# Altium schematic sheet cross_connect.SchDoc
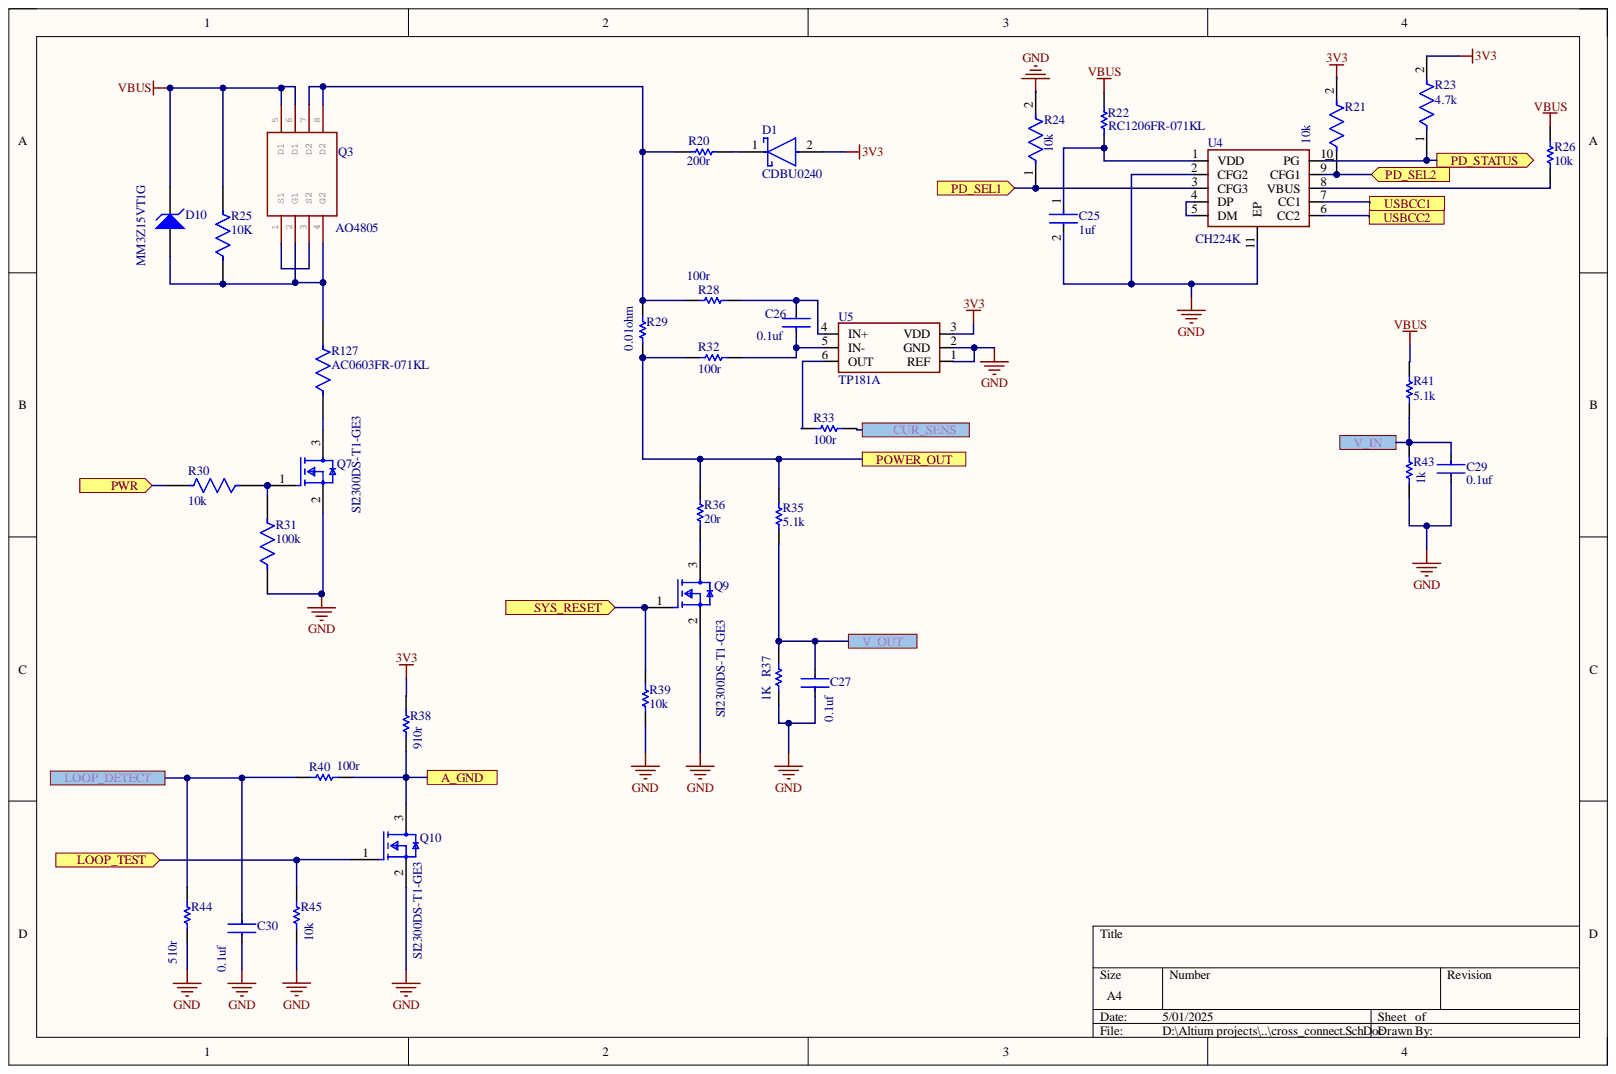
[diagram p0: cross_connect.SchDoc]

## Parts
C25  1uf  [FP-GRM188-0_1-e0_2_0_55-IPC_C]
C26  0.1uf  [FP-GRM188-0_1-e0_2_0_55-IPC_C]
C27  0.1uf  [FP-GRM188-0_1-e0_2_0_55-IPC_C]
C29  0.1uf  [FP-GRM188-0_1-e0_2_0_55-IPC_C]
C30  0.1uf  [FP-GRM188-0_1-e0_2_0_55-IPC_C]
D1  CDBU0240  [FP-SOD-523F-MFG]
D10  MM3Z15VT1G  [ONSC-SOD-323-2-477-02_V]
Q3  AO4805  [SOP8]
Q7  SI2300DS-T1-GE3  [FP-S-03946-MFG]
Q9  SI2300DS-T1-GE3  [FP-S-03946-MFG]
Q10  SI2300DS-T1-GE3  [FP-S-03946-MFG]
R20  200r  [RESC1609X50X30NL8T20]
R21  10k  [FP-RC0603-0_55-MFG]
R22  RC1206FR-071KL  [RESC3116X65X40ML10T20]
R23  4.7k  [FP-AC0603-MFG]
R24  10k  [FP-RC0603-0_55-MFG]
R25  10K  [FP-RC0603-0_55-MFG]
R26  10k  [RESC1609X50X30NL8T20]
R28  100r  [RESC1609X50X30NL8T20]
R29  0.01ohm  [RESC3216X70X50LL05T20]
R30  10k  [FP-RC0603-0_55-MFG]
R31  100k  [FP-RC0603-0_55-MFG]
R32  100r  [RESC1609X50X30NL8T20]
R33  100r  [RESC1609X50X30NL8T20]
R35  5.1k  [RESC1609X50X30NL8T20]
R36  20r  [RESC3216X70X50LL05T20]
R37  1K  [RESC1609X50X30NL8T20]
R38  910r  [RESC1609X50X30NL8T20]
R39  10k  [RESC1609X50X30NL8T20]
R40  100r  [RESC1609X50X30NL8T20]
R41  5.1k  [RESC1609X50X30NL8T20]
R43  1k  [RESC1609X50X30NL8T20]
R44  510r  [RESC1609X50X30NL8T20]
R45  10k  [RESC1609X50X30NL8T20]
R127  AC0603FR-071KL  [FP-AC0603-IPC_B]
U4  CH224K  [SOP10]
U5  TP181A  [SOP6]

## Ports
A_GND, CUR_SENS, LOOP_DETECT, LOOP_TEST, PD_SEL1, PD_SEL2, PD_STATUS, POWER_OUT, PWR, SYS_RESET, USBCC1, USBCC2, V_IN, V_OUT

## Nets
3V3: D1.2 R21.2 R23.2 R38.2 U5.3(VDD)
GND: C25.2 C27.1 C29.2 C30.2 Q7.2 Q9.2 Q10.2 R24.2 R31.1 R37.1 R39.1 R43.2 R44.1 R45.1 U4.2(CFG2) U4.11(EP) U5.1(REF) U5.2(GND)
VBUS: D10.1 Q3.5(D1) Q3.6(D1) R22.2 R25.2 R26.1 R41.1
A_GND: Q10.3 R38.1 R40.1 ; port A_GND
CUR_SENS: R33.1 ; port CUR_SENS
LOOP_DETECT: C30.1 R40.2 R44.2 ; port LOOP_DETECT
LOOP_TEST: Q10.1 R45.2 ; port LOOP_TEST
PD_SEL1: R24.1 U4.3(CFG3) ; port PD_SEL1
PD_SEL2: R21.1 U4.9(CFG1) ; port PD_SEL2
PD_STATUS: R23.1 U4.10(PG) ; port PD_STATUS
POWER_OUT: R29.2 R32.1 R35.2 R36.2 ; port POWER_OUT
PWR: R30.2 ; port PWR
SYS_RESET: Q9.1 R39.2 ; port SYS_RESET
USBCC1: U4.7(CC1) ; port USBCC1
USBCC2: U4.6(CC2) ; port USBCC2
V_IN: C29.1 R41.2 R43.1 ; port V_IN
V_OUT: C27.2 R35.1 R37.2 ; port V_OUT
NetC25_1: C25.1 R22.1 U4.1(VDD)
NetC26_1: C26.1 R32.2 U5.5(IN-)
NetC26_2: C26.2 R28.2 U5.4(IN+)
NetD1_1: D1.1 R20.1
NetD10_2: D10.2 Q3.2(G1) Q3.4(G2) R25.1 R127.2
NetQ3_1: Q3.1(S1) Q3.3(S2)
NetQ3_7: Q3.7(D2) Q3.8(D2) R20.2 R28.1 R29.1
NetQ7_1: Q7.1 R30.1 R31.2
NetQ7_3: Q7.3 R127.1
NetQ9_3: Q9.3 R36.1
NetR26_2: R26.2 U4.8(VBUS)
NetR33_2: R33.2 U5.6(OUT)
NetU4_4: U4.4(DP) U4.5(DM)

## Library data of the parts
C25 = CMP-2006-02792-2: Chip Multilayer Ceramic Capacitors for General Purpose, 0603, 1uF, X5R, 15%, 20%, 6.3V; Capacitance: 10uF; Case Code (Imperial): 0603; Case Code (Metric): 1608; Case/Package: 0603; Component Kind: Standard; Depth: 0.8mm; Dielectric: X5R; Height: 0.8mm; Lead Free: Lead Free; Length: 1.6mm; Library Name: Altium Content Vault; Material: Ceramic; Max Operating Temperature: 85°C; Min Operating Temperature: -55°C; Mount: Surface Mount; Package Quantity: 4000; Packaging: Tape and Reel; Radiation Hardening: No; REACH SVHC: No SVHC; Termination: SMD/SMT; Thickness: 0.035inch; Tolerance: 20%; Voltage: 6.3V; Voltage Rating: 6.3V; Voltage Rating (DC): 6.3V; Width: 0.031inch
C26 C27 C29 C30 = CMP-2006-02792-2: Chip Multilayer Ceramic Capacitors for General Purpose, 0603, 0.1uF, X5R, 15%, 20%, 6.3V; Capacitance: 10uF; Case Code (Imperial): 0603; Case Code (Metric): 1608; Case/Package: 0603; Component Kind: Standard; Depth: 0.8mm; Dielectric: X5R; Height: 0.8mm; Lead Free: Lead Free; Length: 1.6mm; Library Name: Altium Content Vault; Material: Ceramic; Max Operating Temperature: 85°C; Min Operating Temperature: -55°C; Mount: Surface Mount; Package Quantity: 4000; Packaging: Tape and Reel; Radiation Hardening: No; REACH SVHC: No SVHC; Termination: SMD/SMT; Thickness: 0.035inch; Tolerance: 20%; Voltage: 6.3V; Voltage Rating: 6.3V; Voltage Rating (DC): 6.3V; Width: 0.031inch
D1 = CMP-20227-000007-1: DIODE SCHOTTKY 40V 200MA 0603; Capacitance: 9pF; Max Power Dissipation: 150mW; Max Reverse Leakage Current: 1uA; Max Surge Current: 2A; Polarity: Standard; Average Rectified Current: 200mA; Case/Package: SMD/SMT; Component Kind: Standard; Current Rating: 200mA; Lead Free: Lead Free; Library Name: Altium Content Vault; Max Reverse Voltage (DC): 40V; Mount: Surface Mount; Output Current: 200mA; Package Quantity: 1; Packaging: Tape and Reel; RoHS Compliant: Yes; Voltage Rating (DC): 40V
D10 = CMP-1060-00722-1: Zener Voltage Regulator, 300 mW, 2-Pin SOD-323, Pb-Free, Tape and Reel; Manufacturer: On Semiconductor; P Max (W): 0.3; VZ Typ (V): 15; Component Kind: Standard; ComponentLink1Description: Manufacturer URL; ComponentLink1URL: http://www.onsemi.com/; ComponentLink2Description: Datasheet; ComponentLink2URL: http://www.onsemi.cn/pub_link/Collateral/MM3Z2V4T1-D.PDF; ComponentLink3Description: Package Specification; ComponentLink3URL: http://www.onsemi.com/pub_link/Collateral/477-02.PDF.1; DatasheetVersion: Rev. 9; Library Name: Altium Content Vault; Mounting Technology: Surface Mount; PackageDescription: 2-Pin SOD, Body 1.7 x 1.25 mm; PackageReference: SOD-323-2-477-02; PackageVersion: Rev. H, 03/2007; Packing: Tape and Reel; PartNumber: MM3Z15VT1G; RoHS: Pb-Free
Q7 Q9 Q10 = CMP-02550-000182-1: MOSFET N-CH 30V 3.6A SOT-23; Manufacturer: Vishay; Continuous Drain Current: 3.1A; Depletion Mode: False; Drain To Source Resistance: 0.055Ω; Gate Charge At Vgs: 6.5nC @ 10V; Gate To Source Voltage: 12V; Input Capacitace At Vds: 320pF @ 15V; Max Forward Diode Voltage: 1.2V; Max Junction Temp: +150°C; Number Of Channels: 1; Power Dissipation: 1.1W; Pulse Drain Current: 15A; Reverse Recovery Charge: 5nC; Reverse Recovery Time: 11ns; Rthja Max: 90°C/W; Standoff Height: 0.01mm; Threshold Vgs Max: 1.5V; Threshold Vgs Min: 0.6V; Transconductance: 13S; Turn Off Delay Time: 15ns; Turn On Delay Time: 5ns; Typ Forward Diode Voltage: 0.8V; Automotive: No; Category: Trans; Component Kind: Standard; ComponentLink2Description: Datasheet; ComponentLink2URL: http://www.vishay.com/docs/65701/si2300ds.pdf; Device Class L1: Discrete Semiconductors; Device Class L2: Transistors; Device Class L3: MOSFETs; Digikey Description: MOSFET N-CH 30V 3.6A SOT-23; Drain To Source Breakdown Voltage: 30V; Drain To Source Voltage: 30V; Height: 1.12mm; Ipc Land Pattern Name: SOT95P237X100-3; Lead Free: Yes; Library Name: Altium Content Vault; Manufacturer Part Number: SI2300DS-T1-GE3; Mouser Description: MOSFET 30V 3.6A N-CH MOSFET; Number Of N Channels: 1; Octopart: https://octopart.com/si2300ds-t1-ge3-vishay-44451512; Package: S-03946; RoHS: TRUE; Temperature: -55°C to +150°C; Temperature Range High: +150°C; Temperature Range Low: -55°C
R20 = CMP-1666-00005-4: Chip Resistor, 200 Ohm, +/- 1%, 100 mW, -55 to 155 degC, 0603 (1608 Metric), RoHS, Tape and Reel; Manufacturer: Vishay Semiconductor; Voltage Rating: 75 V; Component Kind: Standard; ComponentLink1Description: Manufacturer URL; ComponentLink1URL: http://www.vishay.com/; ComponentLink2Description: Datasheet; ComponentLink2URL: https://datasheet.ciiva.com/11809/0900766b80d98afc-11809037.pdf; DatasheetVersion: 06/2012; Height: 0.45 mm; Length: 1.55 mm; Library Name: Altium Content Vault; Max Operating Temperature: 155 degC; Min Operating Temperature: -55 degC; Mounting Technology: Surface Mount; Package Quantity: 5000; PackageDescription: 2-Pin Surface Mount Device, Body 1.575 x 0.85 mm; PackageReference: 0603; Packaging: Tape and Reel; REACH SVHC: Unknown; RoHSCompliant: true
R21 R24 R25 R30 R31 = CMP-2100-03664-2: RES 10K OHM 1% 1/10W 0603; Max Power Dissipation: 100mW; Resistance: 10kR; Temperature Coefficient: 100ppm/°C; Voltage Rating: 75V; Voltage Rating (DC): 50V; Case Code (Imperial): 0603; Case Code (Metric): 1608; Case/Package: 0603; Component Kind: Standard; Construction: Rectangular; Depth: 0.8mm; Features: Moisture Resistant; Height: 0.45mm; Lead Free: Lead Free; Lead Pitch: 0.3mm; Length: 1.6mm; Library Name: Altium Content Vault; Max Operating Temperature: 155°C; Military Standard: Not; Min Operating Temperature: -55°C; Number of Pins: 2; Number of Terminations: 2; Packaging: Tape and Reel; Power Rating: 100mW; Resistance Tolerance: 1%; Resistor Type: Thick Film; RoHS Compliant: Yes; Series: RC; Size Code: 0603; Termination: SMD/SMT; Tolerance: 1%; Weight: 7.1E-05oz; Width: 0.8mm
R22 = CMP-2100-03678-1: Chip Resistor, 1 KOhm, +/- 1%, 0.25 W, -55 to 155 degC, 1206 (3216 Metric), RoHS, Tape and Reel; Manufacturer: Yageo; Power Rating: 250mW; Resistance: 1KOhm; Temperature Coefficient: 100ppm/degreesC; Voltage Rating: 200V; Case Code (Imperial): 1206; Case Code (Metric): 3216; Case/Package: 1206; Component Kind: Standard; Composition: ThickFilm; Datasheet URL: http://www.yageo.com/NewPortal/yageodocoutput?fileName=/pdf/R-Chip/PYu-RC_Group_51_RoHS_L_5.pdf; Datasheet Version: Rev. 5, 10/2016; Depth: 1.6mm; Height: 0.55mm; Lead Free: LeadFree; Length: 3.1mm; Library Name: Altium Content Vault; Manufacturer URL: http://www.yageo.com/; Max Operating Temperature: 155degC; Military Standard: Not; Min Operating Temperature: -55degC; Package Description: 2-Pin Surface Mount Device, Body 3.1 x 1.6 mm; Package Reference: 1206.0; Packaging: TapeandReel; REACH SVHC: Unknown; RoHSCompliant: true; Termination: SMD/SMT; Tolerance: 1%
R23 = CMP-2000-04847-3: RES 4.7KΩ 1.00% 0.1W 0603; Max Power Dissipation: 100mW; Resistance: 4.7kR; Temperature Coefficient: 100ppm/°C; Tolerance: 1%; Voltage Rating: 50V; Case Code (Imperial): 0603; Case Code (Metric): 1608; Case/Package: 0603; Component Kind: Standard; Construction: Rectangular; Depth: 0.8mm; Features: Moisture Resistant; Height: 0.022inch; Lead Free: Lead Free; Length: 0.063inch; Library Name: Altium Content Vault; Max Operating Temperature: 155°C; Military Standard: Not; Min Operating Temperature: -55°C; Number of Terminations: 2; Packaging: Tape and Reel; Power Rating: 100mW; Ratings: AEC-Q200; Resistor Type: Thick Film; RoHS Compliant: Yes; Series: AC; Size Code: 0603; Termination: SMD/SMT; Width: 0.031inch
R26 R39 R45 = CMP-1666-00005-4: Chip Resistor, 10 KOhm, +/- 1%, 100 mW, -55 to 155 degC, 0603 (1608 Metric), RoHS, Tape and Reel; Manufacturer: Vishay Semiconductor; Voltage Rating: 75 V; Component Kind: Standard; ComponentLink1Description: Manufacturer URL; ComponentLink1URL: http://www.vishay.com/; ComponentLink2Description: Datasheet; ComponentLink2URL: https://datasheet.ciiva.com/11809/0900766b80d98afc-11809037.pdf; DatasheetVersion: 06/2012; Height: 0.45 mm; Length: 1.55 mm; Library Name: Altium Content Vault; Max Operating Temperature: 155 degC; Min Operating Temperature: -55 degC; Mounting Technology: Surface Mount; Package Quantity: 5000; PackageDescription: 2-Pin Surface Mount Device, Body 1.575 x 0.85 mm; PackageReference: 0603; Packaging: Tape and Reel; REACH SVHC: Unknown; RoHSCompliant: true
R28 R32 R33 R40 = CMP-1666-00005-4: Chip Resistor, 100 Ohm, +/- 1%, 100 mW, -55 to 155 degC, 0603 (1608 Metric), RoHS, Tape and Reel; Manufacturer: Vishay Semiconductor; Voltage Rating: 75 V; Component Kind: Standard; ComponentLink1Description: Manufacturer URL; ComponentLink1URL: http://www.vishay.com/; ComponentLink2Description: Datasheet; ComponentLink2URL: https://datasheet.ciiva.com/11809/0900766b80d98afc-11809037.pdf; DatasheetVersion: 06/2012; Height: 0.45 mm; Length: 1.55 mm; Library Name: Altium Content Vault; Max Operating Temperature: 155 degC; Min Operating Temperature: -55 degC; Mounting Technology: Surface Mount; Package Quantity: 5000; PackageDescription: 2-Pin Surface Mount Device, Body 1.575 x 0.85 mm; PackageReference: 0603; Packaging: Tape and Reel; REACH SVHC: Unknown; RoHSCompliant: true
R29 = CMP-2003-00020-1: 0.01 ohm 1206; Manufacturer: Panasonic; Part Number: ERJ-8ENF1004V; Component Kind: Standard; Library Name: Altium Content Vault
R35 = CMP-1666-00005-4: Chip Resistor, 5.1 KOhm, +/- 1%, 100 mW, -55 to 155 degC, 0603 (1608 Metric), RoHS, Tape and Reel; Manufacturer: Vishay Semiconductor; Voltage Rating: 75 V; Component Kind: Standard; ComponentLink1Description: Manufacturer URL; ComponentLink1URL: http://www.vishay.com/; ComponentLink2Description: Datasheet; ComponentLink2URL: https://datasheet.ciiva.com/11809/0900766b80d98afc-11809037.pdf; DatasheetVersion: 06/2012; Height: 0.45 mm; Length: 1.55 mm; Library Name: Altium Content Vault; Max Operating Temperature: 155 degC; Min Operating Temperature: -55 degC; Mounting Technology: Surface Mount; Package Quantity: 5000; PackageDescription: 2-Pin Surface Mount Device, Body 1.575 x 0.85 mm; PackageReference: 0603; Packaging: Tape and Reel; REACH SVHC: Unknown; RoHSCompliant: true
R36 = CMP-2003-00020-1: 20r 1206; Manufacturer: Panasonic; Part Number: ERJ-8ENF1004V; Component Kind: Standard; Library Name: Altium Content Vault
R37 R43 = CMP-1666-00005-4: Chip Resistor, 1 KOhm, +/- 1%, 100 mW, -55 to 155 degC, 0603 (1608 Metric), RoHS, Tape and Reel; Manufacturer: Vishay Semiconductor; Voltage Rating: 75 V; Component Kind: Standard; ComponentLink1Description: Manufacturer URL; ComponentLink1URL: http://www.vishay.com/; ComponentLink2Description: Datasheet; ComponentLink2URL: https://datasheet.ciiva.com/11809/0900766b80d98afc-11809037.pdf; DatasheetVersion: 06/2012; Height: 0.45 mm; Length: 1.55 mm; Library Name: Altium Content Vault; Max Operating Temperature: 155 degC; Min Operating Temperature: -55 degC; Mounting Technology: Surface Mount; Package Quantity: 5000; PackageDescription: 2-Pin Surface Mount Device, Body 1.575 x 0.85 mm; PackageReference: 0603; Packaging: Tape and Reel; REACH SVHC: Unknown; RoHSCompliant: true
R38 = CMP-1666-00005-4: Chip Resistor, 910 Ohm, +/- 1%, 100 mW, -55 to 155 degC, 0603 (1608 Metric), RoHS, Tape and Reel; Manufacturer: Vishay Semiconductor; Voltage Rating: 75 V; Component Kind: Standard; ComponentLink1Description: Manufacturer URL; ComponentLink1URL: http://www.vishay.com/; ComponentLink2Description: Datasheet; ComponentLink2URL: https://datasheet.ciiva.com/11809/0900766b80d98afc-11809037.pdf; DatasheetVersion: 06/2012; Height: 0.45 mm; Length: 1.55 mm; Library Name: Altium Content Vault; Max Operating Temperature: 155 degC; Min Operating Temperature: -55 degC; Mounting Technology: Surface Mount; Package Quantity: 5000; PackageDescription: 2-Pin Surface Mount Device, Body 1.575 x 0.85 mm; PackageReference: 0603; Packaging: Tape and Reel; REACH SVHC: Unknown; RoHSCompliant: true
R41 = CMP-1666-00005-4: Chip Resistor, 5.1k Ohm, +/- 1%, 100 mW, -55 to 155 degC, 0603 (1608 Metric), RoHS, Tape and Reel; Manufacturer: Vishay Semiconductor; Voltage Rating: 75 V; Component Kind: Standard; ComponentLink1Description: Manufacturer URL; ComponentLink1URL: http://www.vishay.com/; ComponentLink2Description: Datasheet; ComponentLink2URL: https://datasheet.ciiva.com/11809/0900766b80d98afc-11809037.pdf; DatasheetVersion: 06/2012; Height: 0.45 mm; Length: 1.55 mm; Library Name: Altium Content Vault; Max Operating Temperature: 155 degC; Min Operating Temperature: -55 degC; Mounting Technology: Surface Mount; Package Quantity: 5000; PackageDescription: 2-Pin Surface Mount Device, Body 1.575 x 0.85 mm; PackageReference: 0603; Packaging: Tape and Reel; REACH SVHC: Unknown; RoHSCompliant: true
R44 = CMP-1666-00005-4: Chip Resistor, 510 Ohm, +/- 1%, 100 mW, -55 to 155 degC, 0603 (1608 Metric), RoHS, Tape and Reel; Manufacturer: Vishay Semiconductor; Voltage Rating: 75 V; Component Kind: Standard; ComponentLink1Description: Manufacturer URL; ComponentLink1URL: http://www.vishay.com/; ComponentLink2Description: Datasheet; ComponentLink2URL: https://datasheet.ciiva.com/11809/0900766b80d98afc-11809037.pdf; DatasheetVersion: 06/2012; Height: 0.45 mm; Length: 1.55 mm; Library Name: Altium Content Vault; Max Operating Temperature: 155 degC; Min Operating Temperature: -55 degC; Mounting Technology: Surface Mount; Package Quantity: 5000; PackageDescription: 2-Pin Surface Mount Device, Body 1.575 x 0.85 mm; PackageReference: 0603; Packaging: Tape and Reel; REACH SVHC: Unknown; RoHSCompliant: true
R127 = CMP-2000-04828-3: RES 1kΩ 1.00% 0.1W 0603; Max Power Dissipation: 100mW; Resistance: 1kR; Temperature Coefficient: 100ppm/°C; Tolerance: 1%; Voltage Rating: 50V; Case Code (Imperial): 0603; Case Code (Metric): 1608; Case/Package: 0603; Component Kind: Standard; Construction: Rectangular; Depth: 0.8mm; Features: Moisture Resistant; Height: 0.022inch; Lead Free: Lead Free; Length: 0.063inch; Library Name: Altium Content Vault; Max Operating Temperature: 155°C; Military Standard: Not; Min Operating Temperature: -55°C; Number of Terminations: 2; Package Quantity: 1; Packaging: Tape and Reel; Power Rating: 100mW; Ratings: AEC-Q200; Resistor Type: Thick Film; RoHS Compliant: Yes; Series: AC; Size Code: 0603; Termination: SMD/SMT; Width: 0.031inch
Q3 = AO4805: Component Kind: Standard; Description: AO4805; Library Name: AON6403.SCHLIB
U4 = CH224K: Component Kind: Standard; Library Name: Schlib1.SchLib
U5 = TP181A: Component Kind: Standard; Library Name: Schlib1.SchLib
